# Altium schematic sheet Ideal Diode.SchDoc("Ideal Diode")
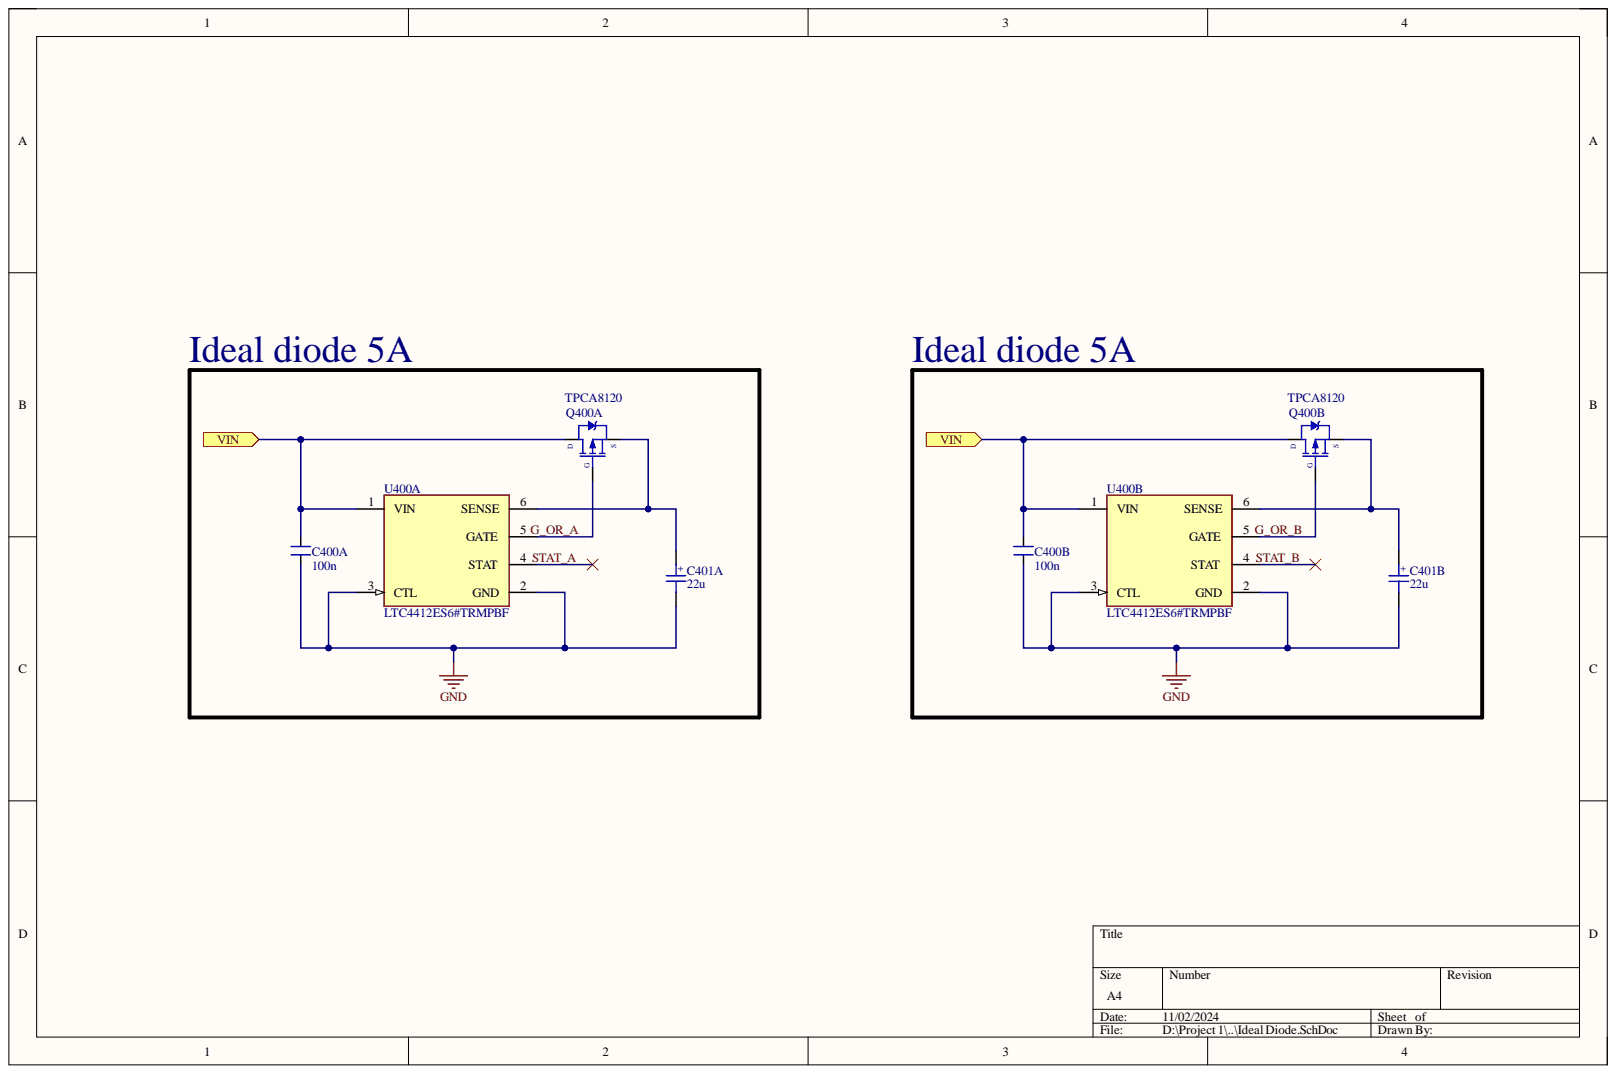
[diagram p0: Ideal Diode.SchDoc]

## Parts
C400A  100n  [CN-SMD-0603]
C400B  100n  [CN-SMD-0603]
C401A  22u  [CAP_TANT_0603]
C401B  22u  [CAP_TANT_0603]
Q400A  TPCA8120  [Mosfet P channel]
Q400B  TPCA8120  [Mosfet P channel]
U400A  LTC4412ES6#TRMPBF  [FP-S6-6-05-08-1636-IPC_C]
U400B  LTC4412ES6#TRMPBF  [FP-S6-6-05-08-1636-IPC_C]

## Ports
VIN

## Nets
GND: C400A.1 C400B.1 C401A.K C401B.K U400A.2(GND) U400A.3(CTL) U400B.2(GND) U400B.3(CTL)
G_OR_A: Q400A.4 U400A.5(GATE)
G_OR_B: Q400B.4 U400B.5(GATE)
STAT_A: U400A.4(STAT)
STAT_B: U400B.4(STAT)
VIN: C400A.2 C400B.2 Q400A.5,6,7,8 Q400B.5,6,7,8 U400A.1(VIN) U400B.1(VIN) ; port VIN
NetC401A_A: C401A.A Q400A.1,2,3 U400A.6(SENSE)
NetC401B_A: C401B.A Q400B.1,2,3 U400B.6(SENSE)

## Library data of the parts
C400A C400B = CN: Value: 33pF; Component Kind: Standard; Library Name: Library.SchLib
C401A C401B = CAP_TANT_0603: Capacitor SMD Tantalum 0603; Component Kind: Standard; Library Name: Schematic-Lib-PhilsLab.SchLib
Q400A Q400B = Mosfet P channel: Component Kind: Standard; Library Name: Library.SchLib
U400A U400B = CMP-0479-00425-2: IC OR CTRLR SRC SELECT TSOT23-6; Max Supply Voltage: 28V; Min Supply Voltage: 2.5V; Number of Channels: 1; Turn-On Delay Time: 110us; Case/Package: TSOT; Component Kind: Standard; Height: 0.9mm; Lead Free: Lead Free; Length: 2.9mm; Library Name: Altium Content Vault; Max Operating Temperature: 85°C; Min Operating Temperature: -40°C; Number of Outputs: 1; Number of Pins: 6; Package Quantity: 1; Packaging: Tape and Reel; Radiation Hardening: No; REACH SVHC: No SVHC; RoHS Compliant: Yes; Series: PowerPath™; Width: 1.75mm
